# Altium schematic sheet 3.3V.SchDoc
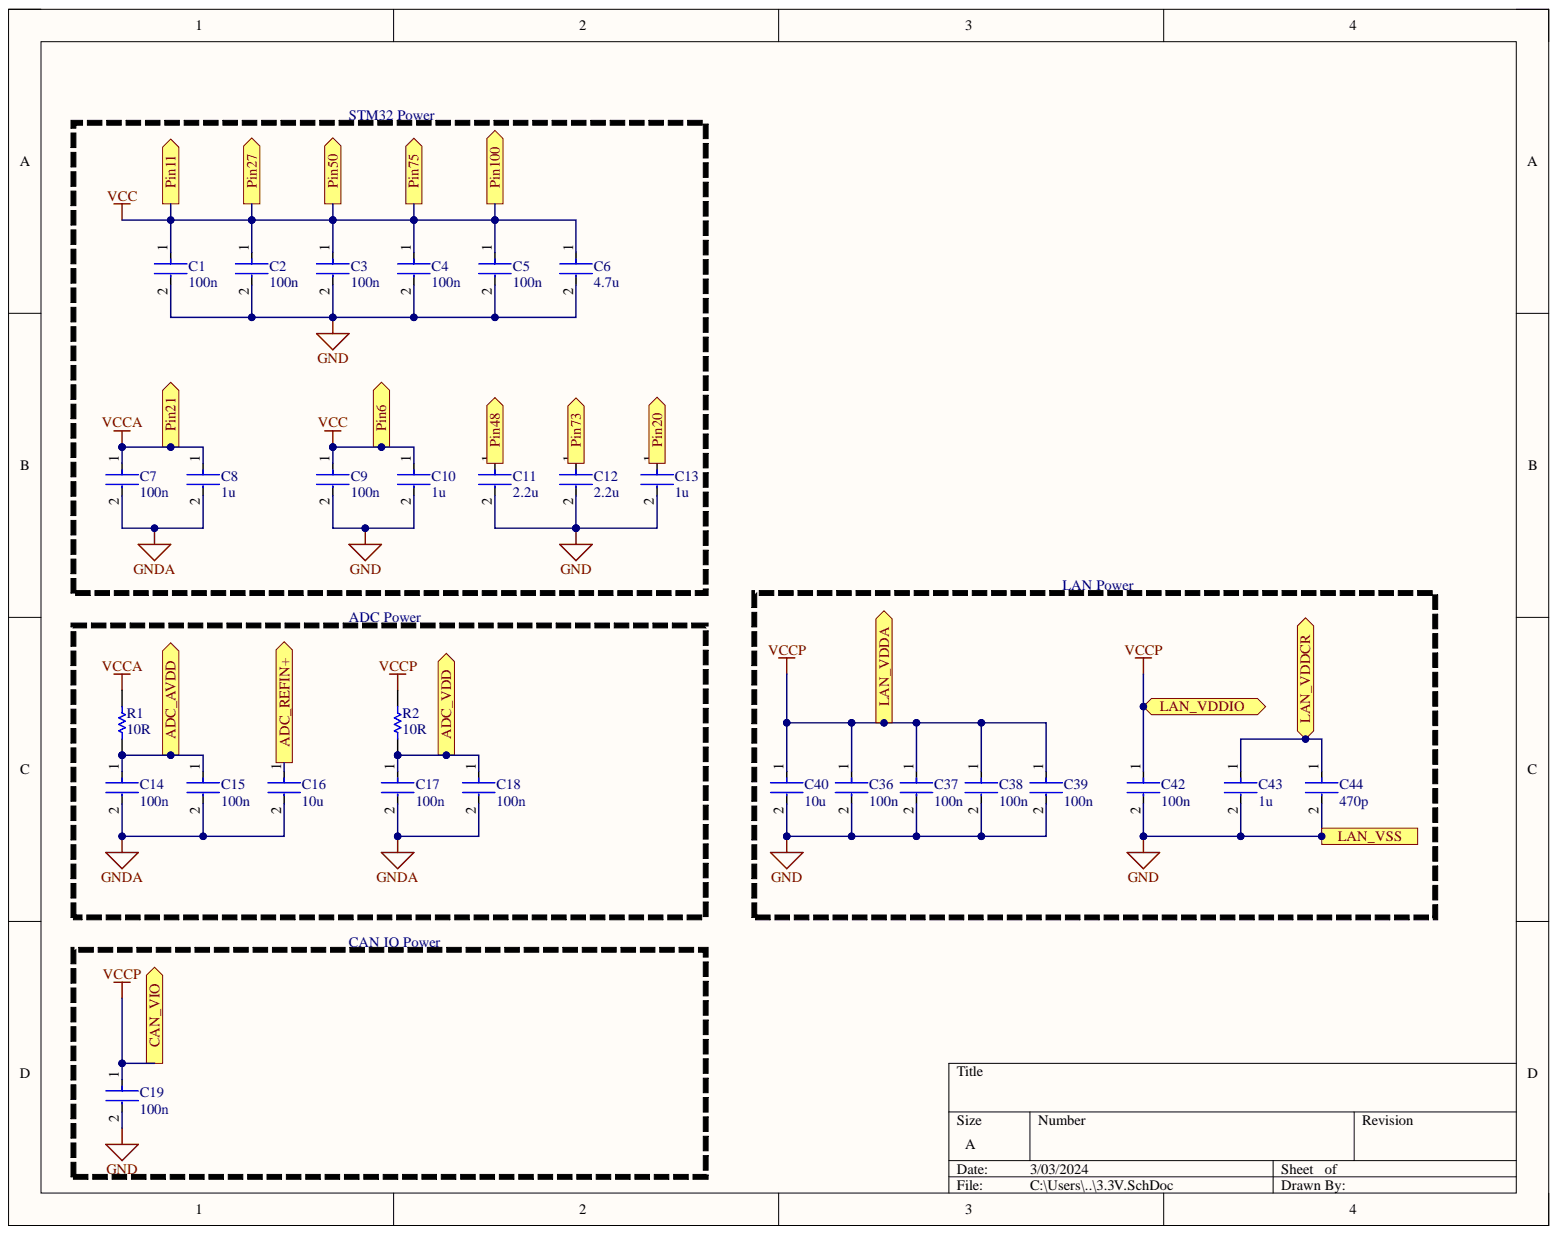
[diagram p0: 3.3V.SchDoc]

## Parts
C1  100n  [FP-GRM033-0_03-MFG]
C2  100n  [FP-GRM033-0_03-MFG]
C3  100n  [FP-GRM033-0_03-MFG]
C4  100n  [FP-GRM033-0_03-MFG]
C5  100n  [FP-GRM033-0_03-MFG]
C6  4.7u  [FP-C1005-050-0_15-MFG]
C7  100n  [FP-GRM033-0_03-MFG]
C8  1u  [FP-C2012-125-0_2-IPC_A]
C9  100n  [FP-GRM033-0_03-MFG]
C10  1u  [FP-C2012-125-0_2-IPC_A]
C11  2.2u  [FP-GRM188-0_1-e0_2_0_5-MFG]
C12  2.2u  [FP-GRM188-0_1-e0_2_0_5-MFG]
C13  1u  [FP-C2012-125-0_2-IPC_A]
C14  100n  [FP-GRM033-0_03-MFG]
C15  100n  [FP-GRM033-0_03-MFG]
C16  10u  [FP-C0805C-DG-MFG]
C17  100n  [FP-GRM033-0_03-MFG]
C18  100n  [FP-GRM033-0_03-MFG]
C19  100n  [FP-GRM033-0_03-MFG]
C36  100n  [FP-GRM033-0_03-MFG]
C37  100n  [FP-GRM033-0_03-MFG]
C38  100n  [FP-GRM033-0_03-MFG]
C39  100n  [FP-GRM033-0_03-MFG]
C40  10u  [FP-C0805C-DG-MFG]
C42  100n  [FP-GRM033-0_03-MFG]
C43  1u  [FP-C1005-050-0_15-MFG]
C44  470p  [FP-C1005-050-0_15-MFG]
R1  10R  [RESC2013X60X35ML10T20]
R2  10R  [RESC2013X60X35ML10T20]

## Ports
ADC_AVDD, ADC_REFIN+, ADC_VDD, CAN_VIO, LAN_VDDA, LAN_VDDCR, LAN_VDDIO, LAN_VSS, Pin6, Pin11, Pin20, Pin21, Pin27, Pin48, Pin50, Pin73, Pin75, Pin100

## Nets
GNDA: C7.2 C8.2 C14.2 C15.2 C16.2 C17.2 C18.2
ADC_AVDD: C14.1 C15.1 R1.2 ; port ADC_AVDD
ADC_REFIN+: C16.1 ; port ADC_REFIN+
ADC_VDD: C17.1 C18.1 R2.2 ; port ADC_VDD
GND: C1.2 C2.2 C3.2 C4.2 C5.2 C6.2 C9.2 C10.2 C11.2 C12.2 C13.2 C19.2 C36.2 C37.2 C38.2 C39.2 C40.2 C42.2 C43.2 C44.2 ; port LAN_VSS
LAN_VDDCR: C43.1 C44.1 ; port LAN_VDDCR
Pin20: C13.1 ; port Pin20
Pin48: C11.1 ; port Pin48
Pin73: C12.1 ; port Pin73
VCC: C1.1 C2.1 C3.1 C4.1 C5.1 C6.1 C9.1 C10.1 ; port Pin100, port Pin11, port Pin27, port Pin50, port Pin6, port Pin75
VCCA: C7.1 C8.1 R1.1 ; port Pin21
VCCP: C19.1 C36.1 C37.1 C38.1 C39.1 C40.1 C42.1 R2.1 ; port CAN_VIO, port LAN_VDDA, port LAN_VDDIO

## Library data of the parts
C1-C5 C7 C9 C14 C15 C17-C19 C36-C39 C42 = CMP-06035-000062-2: Chip Multilayer Ceramic Capacitors for General Purpose, 0201, 0.10uF, X5R, 15%, 10%, 6.3V; Capacitance: 100nF; Case Code (Imperial): 0201; Case Code (Metric): 0603; Case/Package: 0201; Component Kind: Standard; Depth: 0.3mm; Dielectric: X5R; Height: 0.3mm; Lead Free: Lead Free; Length: 0.6mm; Library Name: Altium Content Vault; Material: Ceramic; Max Operating Temperature: 85°C; Min Operating Temperature: -55°C; Mount: Surface Mount; Package Quantity: 1; Packaging: Tape and Reel; Radiation Hardening: No; REACH SVHC: No SVHC; Termination: SMD/SMT; Thickness: 0.013inch; Tolerance: 10%; Voltage: 6.3V; Voltage Rating: 6.3V; Voltage Rating (DC): 6.3V; Weight: 6E-06oz; Width: 0.012inch
C6 C43 C44 = CMP-2000-05997-2: CAP CER 4.7UF 10V X5R 0402; Dielectric Material: Ceramic; Tolerance: 10%; Capacitance: 4.7uF; Case Code (Imperial): 0402; Case Code (Metric): 1005; Case/Package: 0402; Component Kind: Standard; Dielectric: X5R; Lead Free: Lead Free; Length: 0.039inch; Library Name: Altium Content Vault; Max Operating Temperature: 85°C; Min Operating Temperature: -55°C; Mount: Surface Mount; Package Quantity: 10000; Packaging: Cut Tape; REACH SVHC: No SVHC; RoHS Compliant: Yes; Series: C; Thickness: 0.022inch; Voltage Rating: 10V; Voltage Rating (DC): 10V; Width: 0.02inch
C8 C10 C13 = CMP-08246-005389-1: Multilayer Ceramic Capacitors 1μF ±10% 25V X5R SMD 0805; Capacitance: 1uF; Case Code (Imperial): 0805; Case Code (Metric): 2012; Case/Package: 0805; Component Kind: Standard; Construction: Flat; Depth: 1.25mm; Dielectric: X5R; Dielectric Material: Ceramic; Height: 1.25mm; Lead Free: Lead Free; Length: 2mm; Library Name: Altium Content Vault; Max Operating Temperature: 85°C; Min Operating Temperature: -55°C; Mount: Surface Mount; Number of Pins: 2; Package Quantity: 2000; Packaging: Tape and Reel; Radiation Hardening: No; REACH SVHC: No SVHC; Resistor Type: Ceramic; RoHS Compliant: Yes; Series: C; Termination: SMD/SMT; Thickness: 0.057inch; Tolerance: 10%; Voltage: 25V; Voltage Rating: 25V; Voltage Rating (DC): 25V; Weight: 0.000194oz; Width: 0.049inch
C11 C12 = CMP-2006-02836-2: Chip Multilayer Ceramic Capacitors for General Purpose, 0603, 2.2uF, X7R, 15%, 10%, 10V; Capacitance: 2.2uF; Case Code (Imperial): 0603; Case Code (Metric): 1608; Case/Package: 0603; Component Kind: Standard; Depth: 0.8mm; Dielectric: X7R; Height: 0.8mm; Lead Free: Lead Free; Length: 1.6mm; Library Name: Altium Content Vault; Material: Ceramic; Max Operating Temperature: 125°C; Min Operating Temperature: -55°C; Mount: Surface Mount; Number of Pins: 2; Package Quantity: 1; Packaging: Cut Tape; REACH SVHC: No SVHC; Termination: SMD/SMT; Thickness: 0.035inch; Tolerance: 10%; Voltage: 10V; Voltage Rating: 10V; Voltage Rating (DC): 10V; Weight: 7.1E-05oz; Width: 0.031inch
C16 C40 = CMP-2007-03243-2: CAP CER 10UF 10V X5R 0805; 3DS Part Number: 220-00001; Manufacturer: Kemet; Manufacturer Part Number: C0805C106K8PACTU; Material: Ceramic; Temperature Coefficient: 15%; Tolerance: 10%; Automotive: No; Capacitance: 10uF; Category: Cap; Component Kind: Standard; ComponentLink2Description: Datasheet; ComponentLink2URL: https://content.kemet.com/datasheets/KEM_C1006_X5R_SMD.pdf; Device Class L1: Passive Components; Device Class L2: Capacitors; Device Class L3: Ceramic Capacitors; Digikey Description: CAP CER 10UF 10V X5R 0805; Height: 1.4mm; Ipc Land Pattern Name: CAPC200125X125; Lead Free: Yes; Library Name: Altium Content Vault; Mouser Description: CAP CER 10UF 10V X5R 0805; Octopart: https://octopart.com/c0805c106k8pactu-kemet-98697; Package: C0805C-DG; RoHS: TRUE; Temperature: -55°C to +85°C; Temperature Characteristic: X5R; Temperature Range High: +85°C; Temperature Range Low: -55°C; Value: 10uF; Voltage: 10V; Voltage Rating: 10V
R1 R2 = CMP-2100-03670-1: Chip Resistor, 10 Ohm, +/- 1%, 0.125 W, -55 to 155 degC, 0805 (2012 Metric), RoHS, Tape and Reel; Manufacturer: Yageo; Max Power Dissipation: 125mW; Resistance: 10Ohm; Temperature Coefficient: 200ppm/degreesC; Voltage Rating: 150V; Case Code (Imperial): 0805; Case Code (Metric): 2012; Case/Package: 0805; Component Kind: Standard; Composition: ThickFilm; Datasheet URL: http://www.yageo.com/NewPortal/yageodocoutput?fileName=/pdf/R-Chip/PYu-RC_Group_51_RoHS_L_5.pdf; Datasheet Version: Rev. 5, 10/2016; Depth: 1.25mm; Height: 0.5mm; Lead Free: LeadFree; Length: 2mm; Library Name: Altium Content Vault; Manufacturer URL: http://www.yageo.com/; Max Operating Temperature: 155degC; Min Operating Temperature: -55degC; Number of Pins: 2; Number of Terminations: 2; Package Description: 2-Pin Surface Mounted Device, Body 2 x 1.25 mm; Package Quantity: 1; Package Reference: 0805; Packaging: TapeandReel; Power Rating: 125mW; REACH SVHC: NoSVHC; RoHSCompliant: true; Termination: SMD/SMT; Tolerance: 1%; Voltage Rating (DC): 150V; Width: 1.25mm
